# Altium schematic sheet Sheet2.SchDoc(Sheet2)
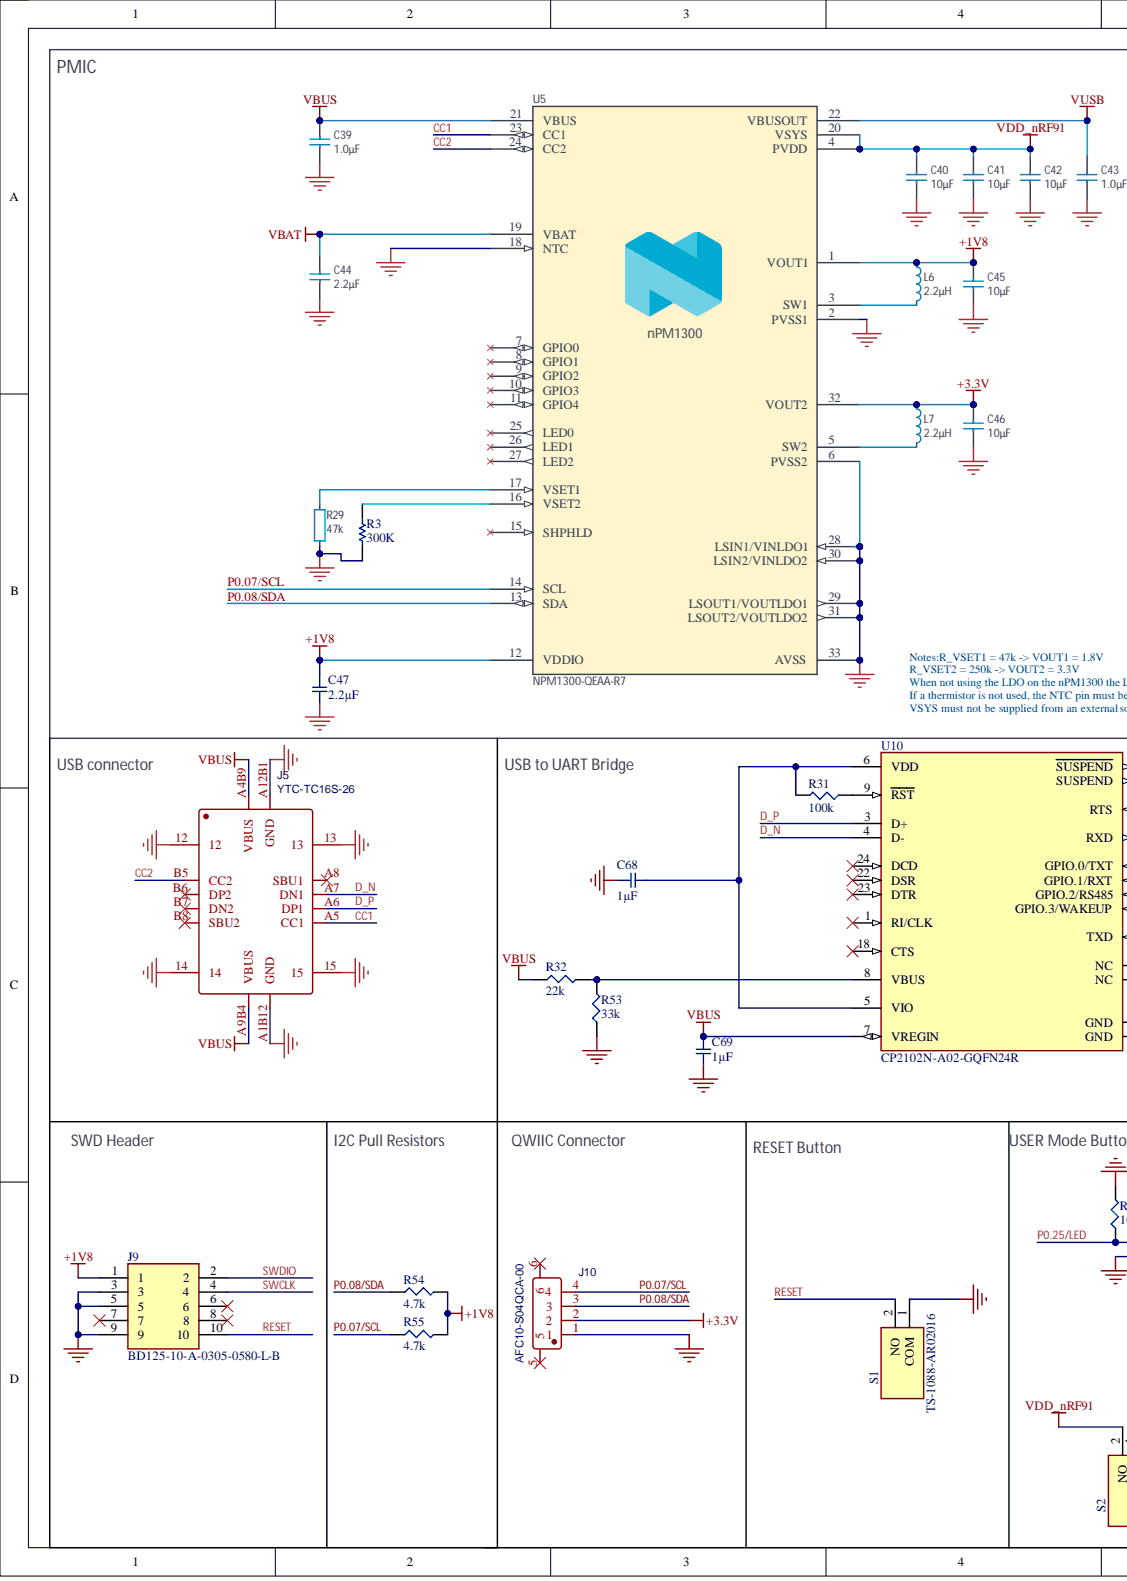
[diagram p0: Sheet2.SchDoc, left]
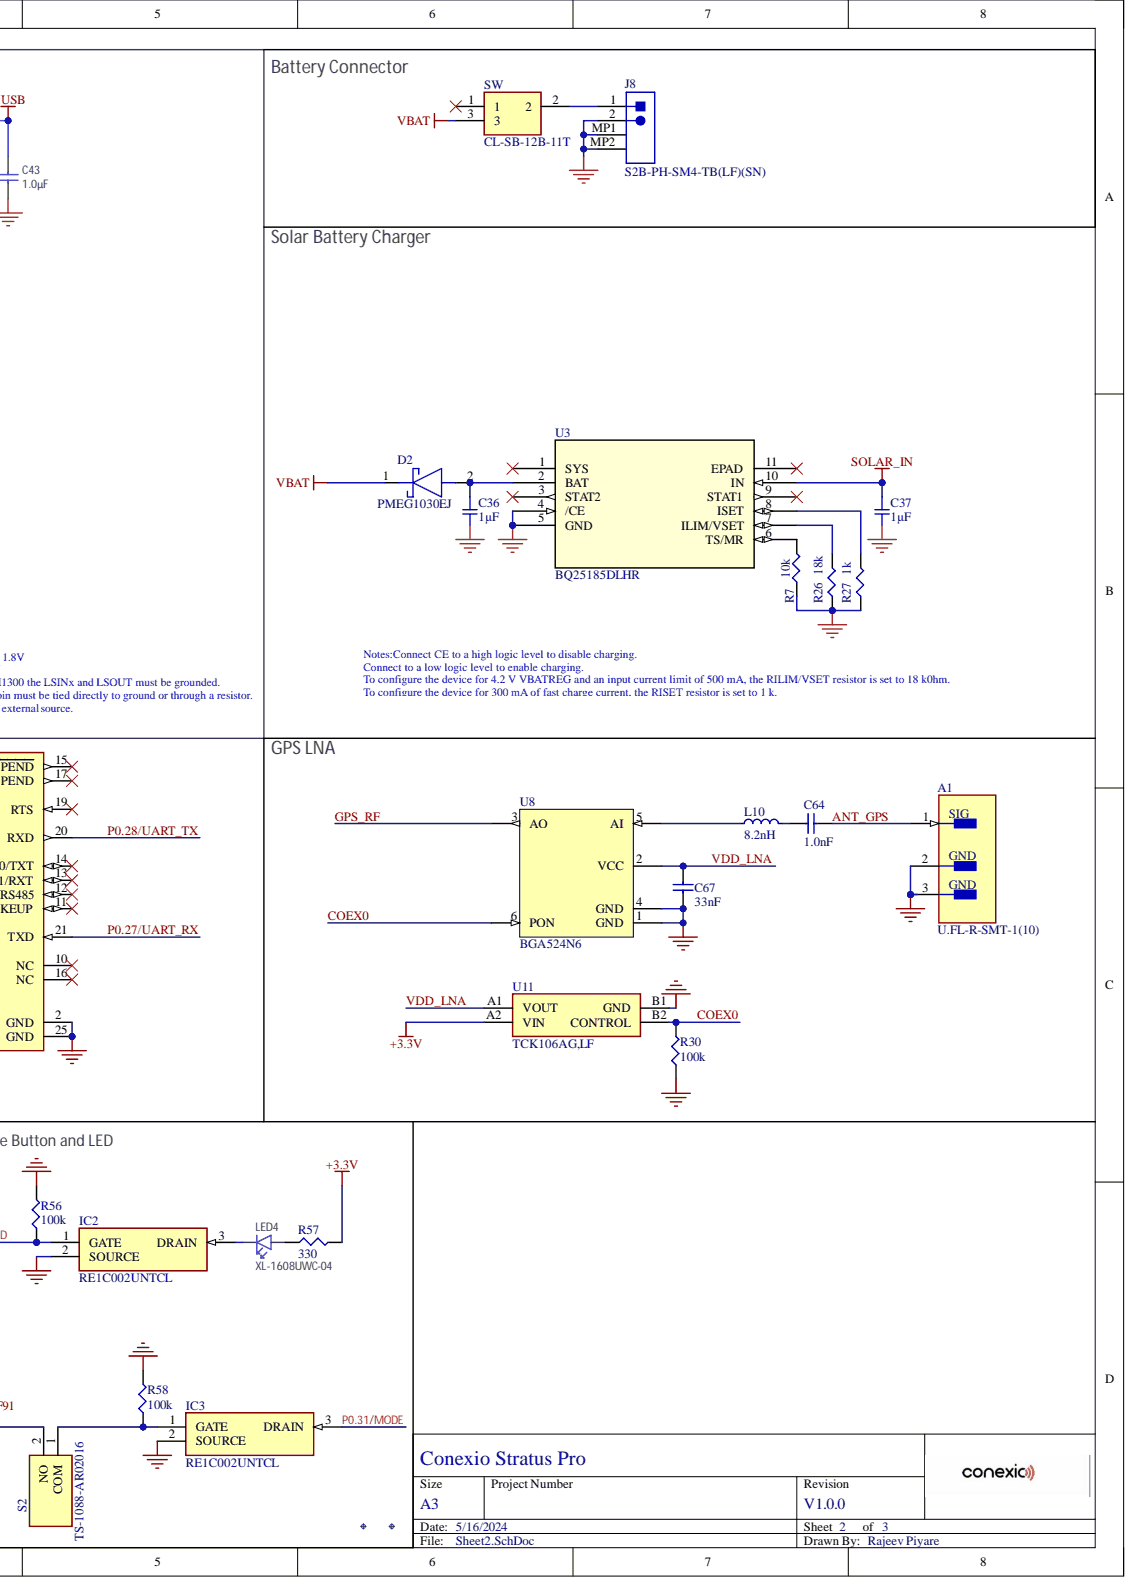
[diagram p1: Sheet2.SchDoc, right]

## Parts
A1  U.FL-R-SMT-1(10)
C36  1µF
C37  1µF
C39  1.0µF
C40  10µF
C41  10µF
C42  10µF
C43  1.0µF
C44  2.2µF
C45  10µF
C46  10µF
C47  2.2µF
C64  1.0nF
C67  33nF
C68  1µF
C69  1µF
D2  PMEG1030EJ
IC2  RE1C002UNTCL
IC3  RE1C002UNTCL
J5  YTC-TC16S-26
J8  S2B-PH-SM4-TB(LF)(SN)
J9  BD125-10-A-0305-0580-L-B
J10  AFC10-S04QCA-00
L6  2.2µH
L7  2.2µH
L10  8.2nH
LED4  XL-1608UWC-04
R3  300K
R7  10k
R26  18k
R27  1k
R29  47k
R30  100k
R31  100k
R32  22k
R53  33k
R54  4.7k
R55  4.7k
R56  100k
R57  330
R58  100k
S1  TS-1088-AR02016
S2  TS-1088-AR02016
SW  CL-SB-12B-11T
U3  BQ25185DLHR
U5  NPM1300-QEAA-R7
U8  BGA524N6
U10  CP2102N-A02-GQFN24R
U11  TCK106AG,LF

## Nets
+1V8: C45.2 C47.1 J9.1 L6.1 R54.2 R55.2 U5.1(VOUT1) U5.12(VDDIO)
+3.3V: C46.2 J10.2 L7.1 R57.2 U5.32(VOUT2) U11.A2(VIN)
GND: A1.2 A1.3 C36.2 C37.2 C39.1 C40.1 C41.1 C42.1 C43.1 C44.1 C45.1 C46.1 C47.2 C67.1 C68.1 C69.2 IC2.2 IC3.2 J5.12 J5.13 J5.14 J5.15 J5.A1B12(GND) J5.A12B1(GND) J8.2 J8.MP1 J8.MP2 J9.3 J9.5 J9.9 J10.1 R3.1 R7.1 R26.1 R27.1 R29.2 R30.1 R53.1 R56.2 R58.2 S1.1 U3.4(/CE) U3.5(GND) U5.2(PVSS1) U5.6(PVSS2) U5.18(NTC) U5.28(LSIN1/VINLDO1) U5.29(LSOUT1/VOUTLDO1) U5.30(LSIN2/VINLDO2) U5.31(LSOUT2/VOUTLDO2) U5.33(AVSS) U8.1(GND) U8.4(GND) U10.2(GND) U10.25(GND) U11.B1(GND)
SOLAR_IN: C37.1 U3.10(IN)
VBAT: C44.2 D2.1 SW.3 U5.19(VBAT)
VBUS: C39.2 C69.1 J5.A4B9(VBUS) J5.A9B4(VBUS) R32.1 U5.21(VBUS) U10.7(VREGIN)
VDD_nRF91: C40.2 C41.2 C42.2 S2.2 U5.4(PVDD) U5.20(VSYS)
VUSB: C43.2 U5.22(VBUSOUT)
ANT_GPS: A1.1 C64.1
CC1: J5.A5(CC1) U5.23(CC1)
CC2: J5.B5(CC2) U5.24(CC2)
COEX0: R30.2 U8.6(PON) U11.B2(CONTROL)
D_N: J5.A7(DN1) U10.4(D-)
D_P: J5.A6(DP1) U10.3(D+)
GPS_RF: U8.3(AO)
P0.07/SCL: J10.4 R55.1 U5.14(SCL)
P0.08/SDA: J10.3 R54.1 U5.13(SDA)
P0.25/LED: IC2.1 R56.1
P0.27/UART_RX: U10.21(TXD)
P0.28/UART_TX: U10.20(RXD)
P0.31/MODE: IC3.3
RESET: J9.10 S1.2
SWCLK: J9.4
SWDIO: J9.2
VDD_LNA: C67.2 U8.2(VCC) U11.A1(VOUT)
NetC36_1: C36.1 D2.2 U3.2(BAT)
NetC64_2: C64.2 L10.2
NetC68_2: C68.2 R31.2 U10.5(VIO) U10.6(VDD)
NetIC2_3: IC2.3 LED4.1
NetIC3_1: IC3.1 R58.1 S2.1
NetJ8_1: J8.1 SW.2
NetL6_2: L6.2 U5.3(SW1)
NetL7_2: L7.2 U5.5(SW2)
NetL10_1: L10.1 U8.5(AI)
NetLED4_2: LED4.2 R57.1
NetR3_2: R3.2 U5.16(VSET2)
NetR7_2: R7.2 U3.6(TS/MR)
NetR26_2: R26.2 U3.7(ILIM/VSET)
NetR27_2: R27.2 U3.8(ISET)
NetR29_1: R29.1 U5.17(VSET1)
NetR31_1: R31.1 U10.9(RST)
NetR32_2: R32.2 R53.2 U10.8(VBUS)

Not connected: J5 A8(SBU1) B6(DP2) B7(DN2) B8(SBU2); J9 6 7 8; J10 5 6; SW 1; U3 1(SYS) 3(STAT2) 9(STAT1) 11(EPAD); U5 7(GPIO0) 8(GPIO1) 9(GPIO2) 10(GPIO3) 11(GPIO4) 15(SHPHLD) 25(LED0) 26(LED1) 27(LED2); U10 1(RI/CLK) 10(NC) 11(GPIO.3/WAKEUP) 12(GPIO.2/RS485) 13(GPIO.1/RXT) 14(GPIO.0/TXT) 15(SUSPEND) 16(NC) 17(SUSPEND) 18(CTS) 19(RTS) 22(DSR) 23(DTR) 24(DCD)

## Library data of the parts
A1 = CMP-2000-05152-3: CONN U.FL RCPT STR 50 OHM SMD; Body Material: Bronze; Body Plating: Silver; Connector Type: Coaxial; Dielectric Material: Polymer; Frequency: 6GHz; Gender: Male; Impedance: 50R; Insulation Resistance: 500MR; Component Kind: Standard; Contact Material: Brass; Contact Plating: Gold; Depth: 3.1mm; Fastening Type: Snap; Housing Color: Beige; Lead Free: Lead Free; Length: 3mm; Library Name: Altium Content Vault; Max Frequency: 6GHz; Max Operating Temperature: 90°C; Min Operating Temperature: -40°C; Mount: Surface Mount; Orientation: Straight; Package Quantity: 2500; Packaging: Cut Tape; Radiation Hardening: No; REACH SVHC: No SVHC; Series: U.FL; Termination: Solder; Weight: 0.001764oz; Wire/Cable Type: Coaxial
C36 C37 C69 = GRT188R61H105ME13D: CAP CER 1UF 50V X5R 0603; Manufacturer: Murata Electronics North America; @ qty: 0; Minimum Quantity: 1; Tolerance: ±20%; Unit Price (USD): 0.24; Applications: Automotive; Component Kind: Standard; Datasheets: http://search.murata.co.jp/Ceramy/image/img/A01X/G101/ENG/GRT188R61H105ME13-01.pdf; Digi-Key Part Number: 490-12331-1-ND; Factory Stock: 0; Features: -; Height - Seated (Max): -; Image: //media.digikey.com/Renders/Murata%20Renders/0603%28LQG18%29.jpg; Lead Spacing: -; Lead Style: -; Library Name: capacitor_murata_0603.IntLib; Mounting Type: Surface Mount, MLCC; Operating Temperature: -55°C ~ 85°C; Package / Case: 0603 (1608 Metric); Packaging: Cut Tape (CT); Part Number: GRT188R61H105ME13D; Part Status: Active; Quantity Available: 3704; Ratings: AEC-Q200; Series: GRT; Size / Dimension: 0.063" L x 0.031" W (1.60mm x 0.80mm); Temperature Coefficient: X5R; Thickness (Max): 0.035" (0.90mm); Value: 1µF; Voltage: 50V
C39 C43 = C0603-1µ0-10V-X5R: Capacitor, Ceramic, 1.0µF 10V X5R 0603,±10%; Part number NEMS: 100200528; Artikkelnr Noca: N.A.; Component Status: Active; Database Table Name: Parts$; Pad Count: 2; Component Class: Capacitors; Component Kind: Standard; ComponentLink1Description: Datasheet; ComponentLink1URL: N.A.; ComponentLink2Description: Supplier link; ComponentLink2URL: N.A.; Footprint Doc: 0603; HelpURL: N.A.; LatestRevisionDate: 2022-10-06; Library Name: AltiumLib.DbLib; Manufacturer: N.A.; Manufacturer Part Number: N.A.; Note: 10V; Part Number: C0603-1µ0-10V-X5R; Part number IPS: N.A.; Part number Norautron: N.A.; PartId: 100200528; Published: 2022-10-06; Supplier 1: N.A.; Supplier 2: N.A.; Supplier Part Number 1: N.A.; Supplier Part Number 2: N.A.; Value: 1.0µF; Author: getr
C40-C42 C45 C46 = C0603-10µ: Capacitor, X5R, ±20%; Manufacturer: N.A.; Part number IPS: 00000007785; Part number NEMS: 100200275; Artikkelnr Noca: 20039234; Component Status: Active; Database Table Name: Parts$; Note: 6.3V; Pad Count: 2; Component Class: Capacitors; Component Kind: Standard; ComponentLink1Description: Datasheet; ComponentLink1URL: N.A.; ComponentLink2Description: Supplier link; ComponentLink2URL: N.A.; Footprint Doc: 0603; HelpURL: N.A.; LatestRevisionDate: 2011-01-17; Library Name: AltiumLib.DbLib; Manufacturer Part Number: N.A.; Part Number: C0603-10µ; Part number Norautron: N.A.; PartId: 100200275; Published: 2011-01-17; Supplier 1: N.A.; Supplier 2: N.A.; Supplier Part Number 1: N.A.; Supplier Part Number 2: N.A.; Value: 10µF; Author: rsk
C44 = C0603-2.2µ-16V-X7R: Capacitor, Ceramic, X7R, ±10%, 16V; Manufacturer: Taiyo Yuden; Manufacturer Part Number: EMK107BB7225KA-T; Part number NEMS: 100200439; Artikkelnr Noca: N.A.; Component Status: Active; Database Table Name: Parts$; Pad Count: 2; Component Class: Capacitors; Component Kind: Standard; ComponentLink1Description: Datasheet; ComponentLink1URL: https://ds.yuden.co.jp/TYCOMPAS/ut/specSheet?pn=EMK107BB7225KA-T; ComponentLink2Description: Supplier link; ComponentLink2URL: https://ds.yuden.co.jp/TYCOMPAS/ut/detail?pn=EMK107BB7225KA-T&u=M; Footprint Doc: 0603; HelpURL: https://www.digikey.no/product-detail/en/taiyo-yuden/EMK107BB7225KA-T/587-5835-2-ND/4970646; LatestRevisionDate: 2020-10-13; Library Name: AltiumLib.DbLib; Note: 16V; Part Number: C0603-2.2µ-16V-X7R; Part number IPS: N.A.; Part number Norautron: N.A.; PartId: 100200439; Published: 2020-02-03; Supplier 1: Digi-Key; Supplier 2: N.A.; Supplier Part Number 1: 587-5835-2-ND; Supplier Part Number 2: N.A.; Value: 2.2µF; Author: rsk
C47 = GRM188C71E225KE11D: CAP CER 2.2UF 25V X7S 0603; Manufacturer: Murata Electronics North America; @ qty: 0; Minimum Quantity: 1; Tolerance: ±10%; Unit Price (USD): 0.25; Applications: General Purpose; Component Kind: Standard; Datasheets: http://www.murata.com/~/media/webrenewal/support/library/catalog/products/capacitor/mlcc/c02e.pdf; Digi-Key Part Number: 490-12732-1-ND; Factory Stock: 0; Features: -; Height - Seated (Max): -; Image: //media.digikey.com/Renders/Murata%20Renders/ZRB-SERIES-0402.jpg; Lead Spacing: -; Lead Style: -; Library Name: capacitor_murata_0603.IntLib; Mounting Type: Surface Mount, MLCC; Operating Temperature: -55°C ~ 125°C; Package / Case: 0603 (1608 Metric); Packaging: Cut Tape (CT); Part Number: GRM188C71E225KE11D; Part Status: Active; Quantity Available: 14750; Ratings: -; Series: GRM; Size / Dimension: 0.063" L x 0.031" W (1.60mm x 0.80mm); Temperature Coefficient: X7S; Thickness (Max): 0.039" (1.00mm); Value: 2.2µF; Voltage: 25V
C64 = C0201-1n0: Capacitor, X7R, ±10%, 25V; Part number IPS: 00000003206; Artikkelnr Noca: N.A.; Database Table Name: Parts$; Note: 16V; Pad Count: 2; Component Class: Capacitors; Component Kind: Standard; ComponentLink1Description: Datasheet; ComponentLink1URL: N.A.; ComponentLink2Description: Supplier link; ComponentLink2URL: N.A.; Footprint Doc: 0201; HelpURL: N.A.; LatestRevisionDate: 2020-10-13; Library Name: AltiumLib.DbLib; Manufacturer: N.A.; Manufacturer Part Number: N.A.; Part Number: C0201-1n0; PartId: 100200056; Published: 2014-11-13; Supplier 1: N.A.; Supplier 2: N.A.; Supplier Part Number 1: N.A.; Supplier Part Number 2: N.A.; Value: 1.0nF
C67 = C0201-33n: Capacitor, X5R, ±10%; Part number IPS: 00000003839; Artikkelnr Noca: N.A.; Database Table Name: Parts$; Note: 10V; Pad Count: 2; Component Class: Capacitors; Component Kind: Standard; ComponentLink1Description: Datasheet; ComponentLink1URL: N.A.; ComponentLink2Description: Supplier link; ComponentLink2URL: N.A.; Footprint Doc: 0201; HelpURL: N.A.; LatestRevisionDate: 2020-01-07; Library Name: AltiumLib.DbLib; Manufacturer: N.A.; Manufacturer Part Number: N.A.; Part Number: C0201-33n; PartId: 100200073; Published: 2014-11-13; Supplier 1: N.A.; Supplier 2: N.A.; Supplier Part Number 1: N.A.; Supplier Part Number 2: N.A.; Value: 33nF
C68 = GRT188C8YA105ME13D: CAP CER 1UF 35V X6S 0603; Manufacturer: Murata Electronics North America; @ qty: 0; Minimum Quantity: 1; Tolerance: ±20%; Unit Price (USD): 0.22; Applications: Automotive; Component Kind: Standard; Datasheets: http://search.murata.co.jp/Ceramy/image/img/A01X/G101/ENG/GRT188C8YA105ME13-01.pdf; Digi-Key Part Number: 490-12298-1-ND; Factory Stock: 0; Features: -; Height - Seated (Max): -; Image: //media.digikey.com/Renders/Murata%20Renders/0603%28LQG18%29.jpg; Lead Spacing: -; Lead Style: -; Library Name: capacitor_murata_0603.IntLib; Mounting Type: Surface Mount, MLCC; Operating Temperature: -55°C ~ 105°C; Package / Case: 0603 (1608 Metric); Packaging: Cut Tape (CT); Part Number: GRT188C8YA105ME13D; Part Status: Active; Quantity Available: 3850; Ratings: AEC-Q200; Series: GRT; Size / Dimension: 0.063" L x 0.031" W (1.60mm x 0.80mm); Temperature Coefficient: X6S; Thickness (Max): 0.035" (0.90mm); Value: 1µF; Voltage: 35V
D2 = PMEG1030EJ: Schottky Diode; Manufacturer_Name: Nexperia; Component Kind: Standard; Datasheet Link: https://datasheet.datasheetarchive.com/originals/distributors/Datasheets-20/DSA-380107.pdf; Height: 0.8mm; Manufacturer_Part_Number: PMEG1030EJ; Mouser Part Number: N/A; Mouser Price/Stock: https://www.mouser.com/Search/Refine.aspx?Keyword=N%2FA
IC2 IC3 = RE1C002UNTCL: Integrated Circuit; Manufacturer_Name: ROHM Semiconductor; Component Kind: Standard; Datasheet Link: https://datasheet.datasheetarchive.com/originals/distributors/DKDS-18/356850.pdf; Height: 0.85mm; Manufacturer_Part_Number: RE1C002UNTCL; Mouser Part Number: 755-RE1C002UNTCL; Mouser Price/Stock: https://www.mouser.co.uk/ProductDetail/ROHM-Semiconductor/RE1C002UNTCL?qs=npTsUczJOtMVMYYXsT30%252BQ%3D%3D
J5 = YTC-TC16S-26: 5A 1 Surface Mount，Right Angle 16P Female -20℃~+85℃ Type-C SMD USB Connectors ROHS; Manufacturer: YIYUAN(怡远); Contributor: lcsc; Component Kind: Standard; JLCPCB Part Class: Extended Part; Library Name: YTC-TC16S-26.IntLib; Manufacturer Part: YTC-TC16S-26; spicePre: U; spiceSymbolName: YTC-TC16S-26; Supplier: LCSC; Supplier Part: C2927292
J8 = CMP-1755-00001-1: Male Header, Pitch 2 mm, 1 x 2 Position, Height 5.5 mm, -25 to 85 degC, RoHS, Tape and Reel; Current Rating: 3 A; Colour: Black; Component Kind: Standard; ComponentLink1Description: Manufacturer URL; ComponentLink1URL: http://www.jst.com/home2.html; ComponentLink2Description: Datasheet; ComponentLink2URL: http://www.jst-mfg.com/product/pdf/eng/ePH.pdf; Contact Finish: Lead; Contact Material: Bronze; Contact Plating: Tin; DatasheetVersion: Dec-12; Height: 8.13 mm; Lead Pitch: 0.1 inch; Length: 101.19 mm; Library Name: Altium Content Vault; Max Operating Temperature: 105degC; Min Operating Temperature: -65degC; Mount: Through Hole; Package Quantity: 100; PackageDescription: Male Header, Pitch 2 mm, 1 x 2 Position, Height 5.5 mm; PackageReference: S2B-PH-SM4-TB(LF)(SN)-2; Packaging: Bulk; Pins: 40; Radiation Hardening: No; RoHSCompliant: True; Width: 2.34 mm
J9 = BD125-10-A-0305-0580-L-B: Connector; Manufacturer_Name: GCT (GLOBAL CONNECTOR TECHNOLOGY); Component Kind: Standard; Datasheet Link: https://gct.co/connector/bd125; Height: 6.37mm; Manufacturer_Part_Number: BD125-10-A-0305-0580-L-B; Mouser Part Number: 640-BD12510A305580LB; Mouser Price/Stock: https://www.mouser.co.uk/ProductDetail/GCT/BD125-10-A-0305-0580-L-B?qs=amGC7iS6iy%252BPUE%252B5wV8wFA%3D%3D
J10 = AFC10-S04QCA-00: Manufacturer: JS(钜硕电子); Contributor: LCSC; Component Kind: Standard; JLCPCB Part Class: Extended Part; Library Name: AFC10-S04QCA-00.SCHLIB; Manufacturer Part: AFC10-S04QCA-00; spicePre: X; spiceSymbolName: AFC10-S04QCA-00; Supplier: LCSC; Supplier Part: C2764183
L6 L7 = L0806-2µ2-2500mA-73mOhm-CIGT201610EH2R2MNE: Inductor, Irms=2.5A, Isat=2.9A, ±20%, DCR=73mΩ; Manufacturer: Samsung Electro-Mechanics America; Part number NEMS: 100300400; Part number Norautron: 26521789; Artikkelnr Noca: N.A.; Component Status: Active; Database Table Name: Parts$; Note: Unshielded Thin Film Inductor; Pad Count: 2; Component Class: Inductors; Component Kind: Standard; ComponentLink1Description: Datasheet; ComponentLink1URL: https://media.digikey.com/pdf/Data%20Sheets/Samsung%20PDFs/CIGT201610EH2R2MNE_Spec.pdf; ComponentLink2Description: Supplier link; ComponentLink2URL: https://www.digikey.com/en/products/detail/samsung-electro-mechanics/CIGT201610EH2R2MNE/7041223?s=N4IgTCBcDaIMIEkDiAVMAGAjANk%2BgogBJgBKYAsgHL4gC6AvkA; Footprint Doc: 0806; HelpURL: http://product.samsungsem.com/pi/CIGT201610EH2R2MN.do; LatestRevisionDate: 2022-09-07; Library Name: AltiumLib.DbLib; Manufacturer Part Number: CIGT201610EH2R2MNE; Part Number: L0806-2µ2-2500mA-73mOhm-CIGT201610EH2R2MNE; Part number IPS: N.A.; PartId: 100300400; Published: 2021-02-03; Supplier 1: Digi-Key; Supplier 2: Mouser; Supplier Part Number 1: 1276-6901-1-ND; Supplier Part Number 2: 187-CIGT201610EH2R2M; Value: 2.2µH; Author: rsk
L10 = L0603-8n2-Wirewound-Murata: Inductor, 800mA, ±0.5nH, 58mΩ, Q=38 @ 250MHz; Manufacturer: Murata; Manufacturer Part Number: LQW18AN8N2D10; Part number IPS: 00000003972; Artikkelnr Noca: N.A.; Database Table Name: Parts$; Note: -55°C ~ 125°C; Pad Count: 2; Component Class: Inductors; Component Kind: Standard; ComponentLink1Description: Datasheet; ComponentLink1URL: https://search.murata.co.jp/Ceramy/image/img/P02/JELF243A-0026.pdf; ComponentLink2Description: Supplier link; ComponentLink2URL: N.A.; Footprint Doc: 0603; HelpURL: https://psearch.en.murata.com/inductor/product/LQW18AN8N2D10%23.html; LatestRevisionDate: 2019-10-25; Library Name: AltiumLib.DbLib; Part Number: L0603-8n2-Wirewound-Murata; PartId: 100300394; Published: 2019-10-25; Supplier 1: Digi-Key; Supplier 2: N.A.; Supplier Part Number 1: 490-6914-1-ND; Supplier Part Number 2: N.A.; Value: 8.2nH
LED4 = VS 25C7M5: Manufacturer: Victory Electronics; Part number IPS: 00000001274, 00000009961; Part number NEMS: 101400097; Part number Norautron: 26313411; Artikkelnr Noca: 13019193; Component Status: Active; Database Table Name: Parts$; Note: N.A.; Pad Count: 2; Component Class: Optoelectronics; Component Kind: Standard; ComponentLink1Description: Datasheet; ComponentLink1URL: N.A.; ComponentLink2Description: Supplier link; ComponentLink2URL: N.A.; Description: XL-1608UWC-04; Footprint Doc: 0603; HelpURL: \\hizz\pro\app9717\Datasheet\optoelectronics\Victory Electronics\VS 25C7M5.pdf; LatestRevisionDate: 2020-10-23; Library Name: AltiumLib.DbLib; Manufacturer Part Number: VS 25C7M5; Part Number: VS 25C7M5; PartId: 101400097; Published: 2017-08-21; Supplier 1: EC Partner; Supplier 2: N.A.; Supplier Part Number 1: N.A.; Supplier Part Number 2: N.A.; Value: XL-1608UWC-04; Author: rsk
R3 = CMP-1010-00133-1: 300K 0.05W 5% 0201 (0603 Metric)  SMD; Case-EIA: 0201; Case-Metric: 0603; Value: 300000.00 Ohm; Component Kind: Standard; Library Name: Altium Content Vault; Power: 0.05 W; Technology: SMT; Tolerance: 5 %
R7 = ERJ-3EKF1002V: RES SMD 10K OHM 1% 1/10W 0603; Manufacturer: Panasonic Electronic Components; @ qty: 1500; Factory Stock: 0; Minimum Quantity: 1; Power (Watts): 0.1W, 1/10W; Temperature Coefficient: ±100ppm/°C; Unit Price (USD): 0.00379; Component Kind: Standard; Composition: Thick Film; Datasheets: http://industrial.panasonic.com/www-cgi/jvcr13pz.cgi?E+PZ+3+AOA0002+ERJ3EKF1002V+7+WW; Digi-Key Part Number: P10.0KHCT-ND; Failure Rate: -; Features: Automotive AEC-Q200; Height - Seated (Max): 0.022" (0.55mm); Image: //media.digikey.com/Photos/Panasonic%20Photos/MFG_ERJ-P6W%20Series.jpg; Library Name: Panasonic_ERJ_0603.IntLib; Number of Terminations: 2; Operating Temperature: -55°C ~ 155°C; Package / Case: 0603 (1608 Metric); Packaging: Cut Tape (CT); Part Number: ERJ-3EKF1002V; Part Status: Active; Quantity Available: 6703141; Series: ERJ; Size / Dimension: 0.063" L x 0.031" W (1.60mm x 0.80mm); Supplier Device Package: 0603; Tolerance: ±1%; Value: 10k
R26 = ERJ-3EKF1802V: RES SMD 18K OHM 1% 1/10W 0603; Manufacturer: Panasonic Electronic Components; @ qty: 1500; Factory Stock: 0; Minimum Quantity: 1; Power (Watts): 0.1W, 1/10W; Temperature Coefficient: ±100ppm/°C; Unit Price (USD): 0.00379; Component Kind: Standard; Composition: Thick Film; Datasheets: http://industrial.panasonic.com/www-cgi/jvcr13pz.cgi?E+PZ+3+AOA0002+ERJ3EKF1802V+7+WW; Digi-Key Part Number: P18.0KHCT-ND; Failure Rate: -; Features: Automotive AEC-Q200; Height - Seated (Max): 0.022" (0.55mm); Image: //media.digikey.com/Photos/Panasonic%20Photos/MFG_ERJ-P6W%20Series.jpg; Library Name: Panasonic_ERJ_0603.IntLib; Number of Terminations: 2; Operating Temperature: -55°C ~ 155°C; Package / Case: 0603 (1608 Metric); Packaging: Cut Tape (CT); Part Number: ERJ-3EKF1802V; Part Status: Active; Quantity Available: 39886; Series: ERJ; Size / Dimension: 0.063" L x 0.031" W (1.60mm x 0.80mm); Supplier Device Package: 0603; Tolerance: ±1%; Value: 18k
R27 = ERJ-3EKF1001V: RES SMD 1K OHM 1% 1/10W 0603; Manufacturer: Panasonic Electronic Components; @ qty: 1500; Factory Stock: 0; Minimum Quantity: 1; Power (Watts): 0.1W, 1/10W; Temperature Coefficient: ±100ppm/°C; Unit Price (USD): 0.00379; Component Kind: Standard; Composition: Thick Film; Datasheets: http://industrial.panasonic.com/www-cgi/jvcr13pz.cgi?E+PZ+3+AOA0002+ERJ3EKF1001V+7+WW; Digi-Key Part Number: P1.00KHCT-ND; Failure Rate: -; Features: Automotive AEC-Q200; Height - Seated (Max): 0.022" (0.55mm); Image: //media.digikey.com/Photos/Panasonic%20Photos/MFG_ERJ-P6W%20Series.jpg; Library Name: Panasonic_ERJ_0603.IntLib; Number of Terminations: 2; Operating Temperature: -55°C ~ 155°C; Package / Case: 0603 (1608 Metric); Packaging: Cut Tape (CT); Part Number: ERJ-3EKF1001V; Part Status: Active; Quantity Available: 5218845; Series: ERJ; Size / Dimension: 0.063" L x 0.031" W (1.60mm x 0.80mm); Supplier Device Package: 0603; Tolerance: ±1%; Value: 1k
R29 = R0201-47k: Resistor, ±1%, 0.05W; Part number IPS: 00000001423; Part number NEMS: 100100076; Artikkelnr Noca: N.A.; Component Status: Active; Database Table Name: Parts$; Note: Chip Res 1%; Pad Count: 2; Component Class: Resistors; Component Kind: Standard; ComponentLink1Description: Datasheet; ComponentLink1URL: N.A.; ComponentLink2Description: Supplier link; ComponentLink2URL: N.A.; Footprint Doc: 0201; HelpURL: N.A.; LatestRevisionDate: 2014-11-13; Library Name: AltiumLib.DbLib; Manufacturer: N.A.; Manufacturer Part Number: N.A.; Part Number: R0201-47k; Part number Norautron: N.A.; PartId: 100100076; Published: 2014-11-13; Supplier 1: N.A.; Supplier 2: N.A.; Supplier Part Number 1: N.A.; Supplier Part Number 2: N.A.; Value: 47k; Author: rsk
R30 R31 R56 R58 = ERJ-3EKF1003V: RES SMD 100K OHM 1% 1/10W 0603; Manufacturer: Panasonic Electronic Components; @ qty: 1500; Factory Stock: 0; Minimum Quantity: 1; Power (Watts): 0.1W, 1/10W; Temperature Coefficient: ±100ppm/°C; Unit Price (USD): 0.00379; Component Kind: Standard; Composition: Thick Film; Datasheets: http://industrial.panasonic.com/www-cgi/jvcr13pz.cgi?E+PZ+3+AOA0002+ERJ3EKF1003V+7+WW; Digi-Key Part Number: P100KHCT-ND; Failure Rate: -; Features: Automotive AEC-Q200; Height - Seated (Max): 0.022" (0.55mm); Image: //media.digikey.com/Photos/Panasonic%20Photos/MFG_ERJ-P6W%20Series.jpg; Library Name: Panasonic_ERJ_0603.IntLib; Number of Terminations: 2; Operating Temperature: -55°C ~ 155°C; Package / Case: 0603 (1608 Metric); Packaging: Cut Tape (CT); Part Number: ERJ-3EKF1003V; Part Status: Active; Quantity Available: 2179804; Series: ERJ; Size / Dimension: 0.063" L x 0.031" W (1.60mm x 0.80mm); Supplier Device Package: 0603; Tolerance: ±1%; Value: 100k
R32 = ERJ-3EKF2202V: RES SMD 22K OHM 1% 1/10W 0603; Manufacturer: Panasonic Electronic Components; @ qty: 1500; Factory Stock: 0; Minimum Quantity: 1; Power (Watts): 0.1W, 1/10W; Temperature Coefficient: ±100ppm/°C; Unit Price (USD): 0.00379; Component Kind: Standard; Composition: Thick Film; Datasheets: http://industrial.panasonic.com/www-cgi/jvcr13pz.cgi?E+PZ+3+AOA0002+ERJ3EKF2202V+7+WW; Digi-Key Part Number: P22.0KHCT-ND; Failure Rate: -; Features: Automotive AEC-Q200; Height - Seated (Max): 0.022" (0.55mm); Image: //media.digikey.com/Photos/Panasonic%20Photos/MFG_ERJ-P6W%20Series.jpg; Library Name: Panasonic_ERJ_0603.IntLib; Number of Terminations: 2; Operating Temperature: -55°C ~ 155°C; Package / Case: 0603 (1608 Metric); Packaging: Cut Tape (CT); Part Number: ERJ-3EKF2202V; Part Status: Active; Quantity Available: 328790; Series: ERJ; Size / Dimension: 0.063" L x 0.031" W (1.60mm x 0.80mm); Supplier Device Package: 0603; Tolerance: ±1%; Value: 22k
R53 = ERJ-3EKF3302V: RES SMD 33K OHM 1% 1/10W 0603; Manufacturer: Panasonic Electronic Components; @ qty: 1500; Factory Stock: 0; Minimum Quantity: 1; Power (Watts): 0.1W, 1/10W; Temperature Coefficient: ±100ppm/°C; Unit Price (USD): 0.00379; Component Kind: Standard; Composition: Thick Film; Datasheets: http://industrial.panasonic.com/www-cgi/jvcr13pz.cgi?E+PZ+3+AOA0002+ERJ3EKF3302V+7+WW; Digi-Key Part Number: P33.0KHCT-ND; Failure Rate: -; Features: Automotive AEC-Q200; Height - Seated (Max): 0.022" (0.55mm); Image: //media.digikey.com/Photos/Panasonic%20Photos/MFG_ERJ-P6W%20Series.jpg; Library Name: Panasonic_ERJ_0603.IntLib; Number of Terminations: 2; Operating Temperature: -55°C ~ 155°C; Package / Case: 0603 (1608 Metric); Packaging: Cut Tape (CT); Part Number: ERJ-3EKF3302V; Part Status: Active; Quantity Available: 240194; Series: ERJ; Size / Dimension: 0.063" L x 0.031" W (1.60mm x 0.80mm); Supplier Device Package: 0603; Tolerance: ±1%; Value: 33k
R54 R55 = ERJ-3EKF4701V: RES SMD 4.7K OHM 1% 1/10W 0603; Manufacturer: Panasonic Electronic Components; @ qty: 1500; Factory Stock: 0; Minimum Quantity: 1; Power (Watts): 0.1W, 1/10W; Temperature Coefficient: ±100ppm/°C; Unit Price (USD): 0.00379; Component Kind: Standard; Composition: Thick Film; Datasheets: http://industrial.panasonic.com/www-cgi/jvcr13pz.cgi?E+PZ+3+AOA0002+ERJ3EKF4701V+7+WW; Digi-Key Part Number: P4.70KHCT-ND; Failure Rate: -; Features: Automotive AEC-Q200; Height - Seated (Max): 0.022" (0.55mm); Image: //media.digikey.com/Photos/Panasonic%20Photos/MFG_ERJ-P6W%20Series.jpg; Library Name: Panasonic_ERJ_0603.IntLib; Number of Terminations: 2; Operating Temperature: -55°C ~ 155°C; Package / Case: 0603 (1608 Metric); Packaging: Cut Tape (CT); Part Number: ERJ-3EKF4701V; Part Status: Active; Quantity Available: 999380; Series: ERJ; Size / Dimension: 0.063" L x 0.031" W (1.60mm x 0.80mm); Supplier Device Package: 0603; Tolerance: ±1%; Value: 4.7k
R57 = ERJ-3EKF3300V: RES SMD 330 OHM 1% 1/10W 0603; Manufacturer: Panasonic Electronic Components; @ qty: 1500; Factory Stock: 0; Minimum Quantity: 1; Power (Watts): 0.1W, 1/10W; Temperature Coefficient: ±100ppm/°C; Unit Price (USD): 0.00379; Component Kind: Standard; Composition: Thick Film; Datasheets: http://industrial.panasonic.com/www-cgi/jvcr13pz.cgi?E+PZ+3+AOA0002+ERJ3EKF3300V+7+WW; Digi-Key Part Number: P330HCT-ND; Failure Rate: -; Features: Automotive AEC-Q200; Height - Seated (Max): 0.022" (0.55mm); Image: //media.digikey.com/Photos/Panasonic%20Photos/MFG_ERJ-P6W%20Series.jpg; Library Name: Panasonic_ERJ_0603.IntLib; Number of Terminations: 2; Operating Temperature: -55°C ~ 155°C; Package / Case: 0603 (1608 Metric); Packaging: Cut Tape (CT); Part Number: ERJ-3EKF3300V; Part Status: Active; Quantity Available: 670984; Series: ERJ; Size / Dimension: 0.063" L x 0.031" W (1.60mm x 0.80mm); Supplier Device Package: 0603; Tolerance: ±1%; Value: 330
S1 S2 = TS-1088-AR02016: Switch; Manufacturer_Name: XUNPU; Component Kind: Standard; Datasheet Link: https://www.xunpudianzi.com/uploads/pdf/TS-1088-ARXXX.pdf; Height: 2mm; Manufacturer_Part_Number: TS-1088-AR02016
SW = CL-SB-12B-11T: Switch; Manufacturer_Name: Copal Electronics; Component Kind: Standard; Datasheet Link: https://www.nidec-copal-electronics.com/e/catalog/switch/cl-sb.pdf; Height: 5mm; Manufacturer_Part_Number: CL-SB-12B-11T
U3 = BQ25185DLHR: Charger IC Multi-Chemistry 10-WSON (2.2x2); Mfr_Name: Texas Instruments; Component Kind: Standard; Library Name: BQ25185DLHR.IntLib; Manufacturer_Part_Number: BQ25185DLHR; RefDes: RefDes; Type: DEV; Copyright: Copyright (C) 2024 Ultra Librarian. All rights reserved.
U5 = nPM1300-QEAACA: Battery Power Management IC Lithium Ion/Polymer 32-QFN (5x5); Manufacturer: Nordic Semiconductor; Part number NEMS: 102000305; Artikkelnr Noca: N.A.; Component Status: Active; Database Table Name: Parts$; Note: Engineering version; Pad Count: 33; Component Class: Nordic_Devices; Component Kind: Standard; ComponentLink1Description: Datasheet; ComponentLink1URL: N.A.; ComponentLink2Description: Supplier link; ComponentLink2URL: N.A.; Footprint Doc: QFN-32; HelpURL: N.A.; LatestRevisionDate: 2023-08-16; Library Name: AltiumLib.DbLib; Manufacturer Part Number: nPM1300-QEAACA; Part Number: nPM1300-QEAACA; Part number IPS: N.A.; Part number Norautron: N.A.; PartId: 102000305; Published: 2023-08-16; Supplier 1: Nordic Semiconductor; Supplier 2: N.A.; Supplier Part Number 1: nPM1300-QEAACA; Supplier Part Number 2: N.A.; Value: nPM1300-QEAACA; Author: eisk
U8 = BGA524N6E6327XTSA1: GPS/GNSS Low-Noise Amplifier, 19.6dB Gain, 0.55dB Noise Figure, 1.5V to 3.3V Supply Range; Manufacturer: Infineon Technologies; Part number IPS: 00000004011; Artikkelnr Noca: N.A.; Database Table Name: Parts$; Pad Count: 6; Component Class: Misc_ICs; Component Kind: Standard; ComponentLink1Description: Datasheet; ComponentLink1URL: https://www.infineon.com/dgdl/Infineon-BGA524N6-DS-v03_00-en.pdf?fileId=db3a304344e406b50144e44dfad302b9; ComponentLink2Description: Supplier link; ComponentLink2URL: N.A.; Footprint Doc: XFDFN-6; HelpURL: https://www.infineon.com/cms/en/product/rf-wireless-control/low-noise-amplifier-lna-ics/gps-glonass-compass-lna/bga524n6/; LatestRevisionDate: 2019-10-25; Library Name: AltiumLib.DbLib; Manufacturer Part Number: BGA524N6E6327XTSA1; Note: N.A.; Part Number: BGA524N6E6327XTSA1; PartId: 101900596; Published: 2019-10-25; Supplier 1: Digi-Key; Supplier 2: Mouser; Supplier Part Number 1: BGA524N6E6327XTSA1CT-ND; Supplier Part Number 2: 726-A524N6E6327XTSA1; Value: BGA524N6
U10 = CMP-08107-000009-1: IC USB TO UART BRIDGE QFN24; Component Kind: Standard; Library Name: Altium Content Vault
U11 = TCK106AG,LF: Integrated Circuit; Manufacturer_Name: Toshiba; Arrow Part Number: TCK106AG,LF; Arrow Price/Stock: https://www.arrow.com/en/products/tck106aglf/toshiba?region=nac; Component Kind: Standard; Datasheet Link: https://toshiba.semicon-storage.com/info/docget.jsp?did=30131&prodName=TCK106AG; Height: 0.59mm; Manufacturer_Part_Number: TCK106AG,LF; Mouser Part Number: 757-TCK106AGLF; Mouser Price/Stock: https://www.mouser.co.uk/ProductDetail/Toshiba/TCK106AGLF?qs=lX6dEUlT%252BgdlAjab8mTlWw%3D%3D
